# Altium schematic sheet Flash - S25FL216K0PMFI041.SchDoc
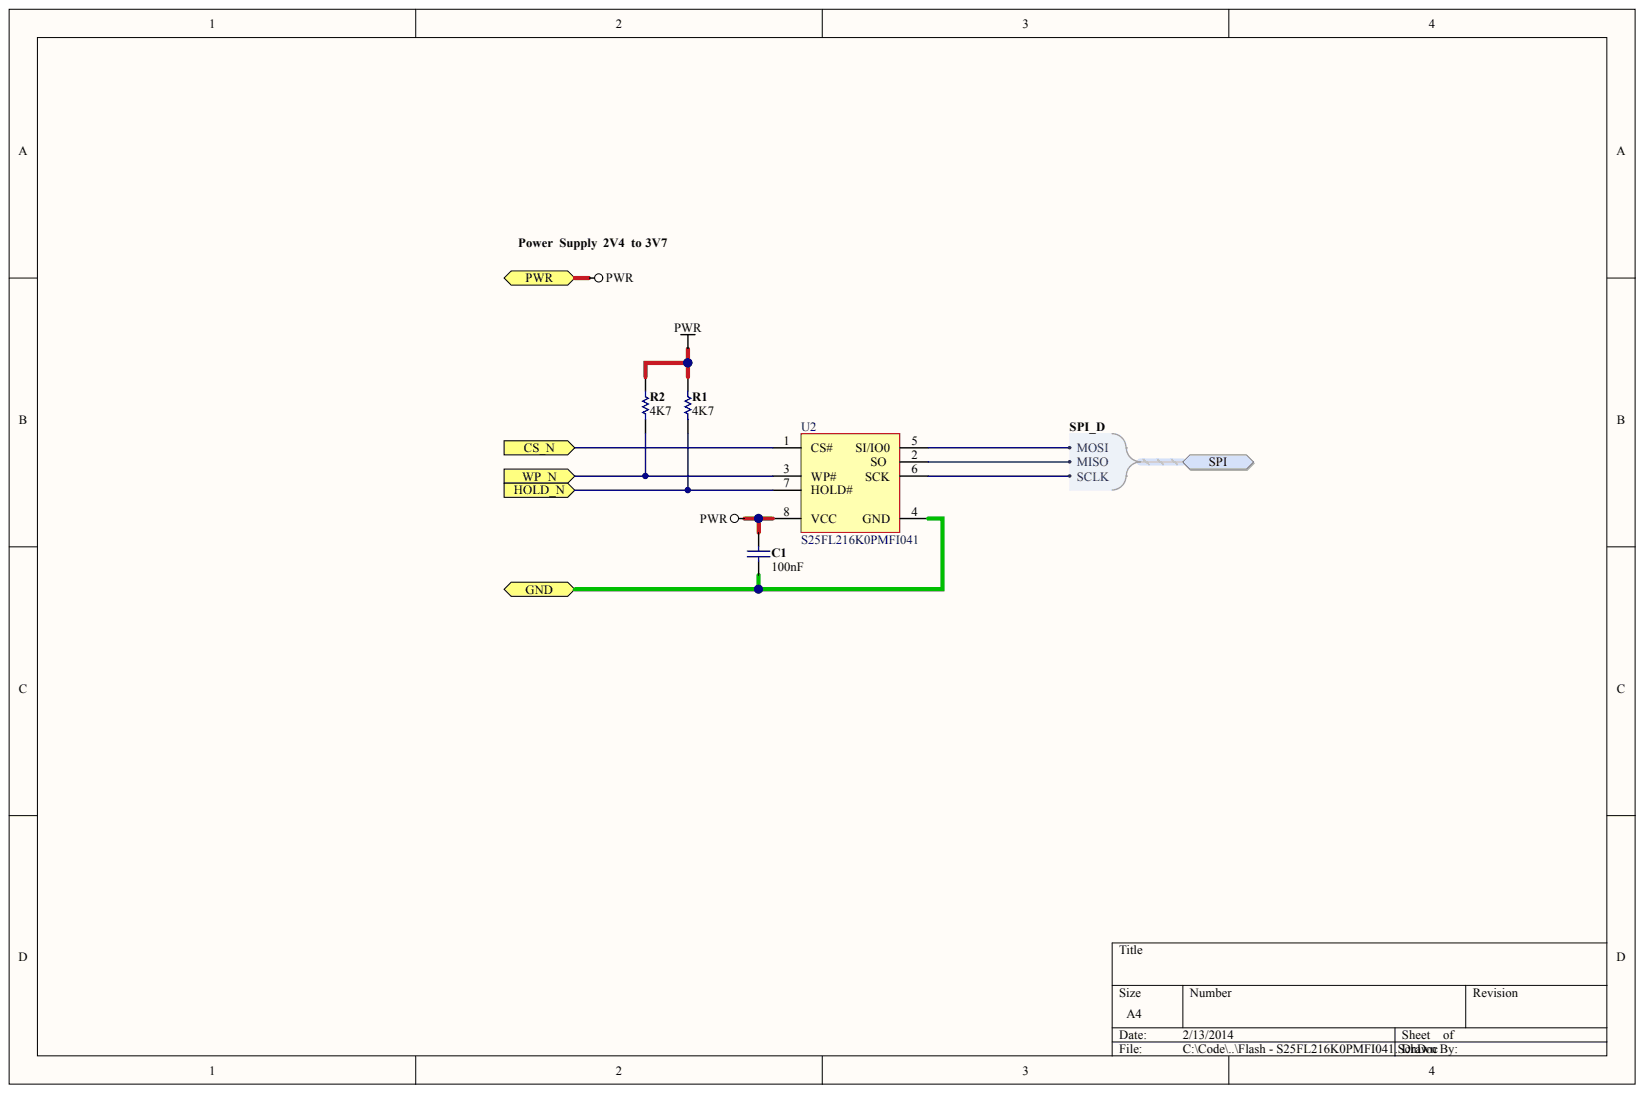
[diagram p0: Flash - S25FL216K0PMFI041.SchDoc]

## Parts
C1  value 100nF  (hidden comment: CAP 100nF 16V 0402(1005))
R1  4K7
R2  4K7
U2  S25FL216K0PMFI041

## Ports
CS_N, GND, HOLD_N, PWR, SPI, SPI.MISO, SPI.MOSI, SPI.SCLK, WP_N

## Nets
CS_N: U2.1(CS#) ; port CS_N
GND: C1.2 U2.4(GND) ; port GND
HOLD_N: R1.1 U2.7(HOLD#) ; port HOLD_N
PWR: C1.1 R1.2 R2.2 U2.8(VCC) ; port PWR
WP_N: R2.1 U2.3(WP#) ; port WP_N
NetU2_2: U2.2(SO) ; port SPI
NetU2_5: U2.5(SI/IO0) ; port SPI
NetU2_6: U2.6(SCK) ; port SPI

## Library data of the parts
C1 = (no symbol name): Case-EIA: 0402; Case-Metric: 1005; Tolerance: ±10%; Max Thickness: 0.6 mm; Rated Voltage: 16 V; Technology: SMT
R1 R2 = (no symbol name): Case-EIA: 0402; Case-Metric: 1005; Temp Coefficient ppm/°C: ±100; Tolerance %: 1%; Value (Ohms): 4700; Power: 1/16 W; Value: 4K7; Technology: SMT
U2 = (no symbol name): ComponentLink1Description: Locate on FindChips.com; ComponentLink1URL: http://www.findchips.com/search/s25fl216k0pmfi041; Manufacturer Part Number: S25FL216K0PMFI041; ComponentLink2Description: Locate on Datasheet.Net; ComponentLink2URL: http://www.datasheet.net/document/566207/
